# Altium schematic sheet Microcontroller and Power Management.SchDoc
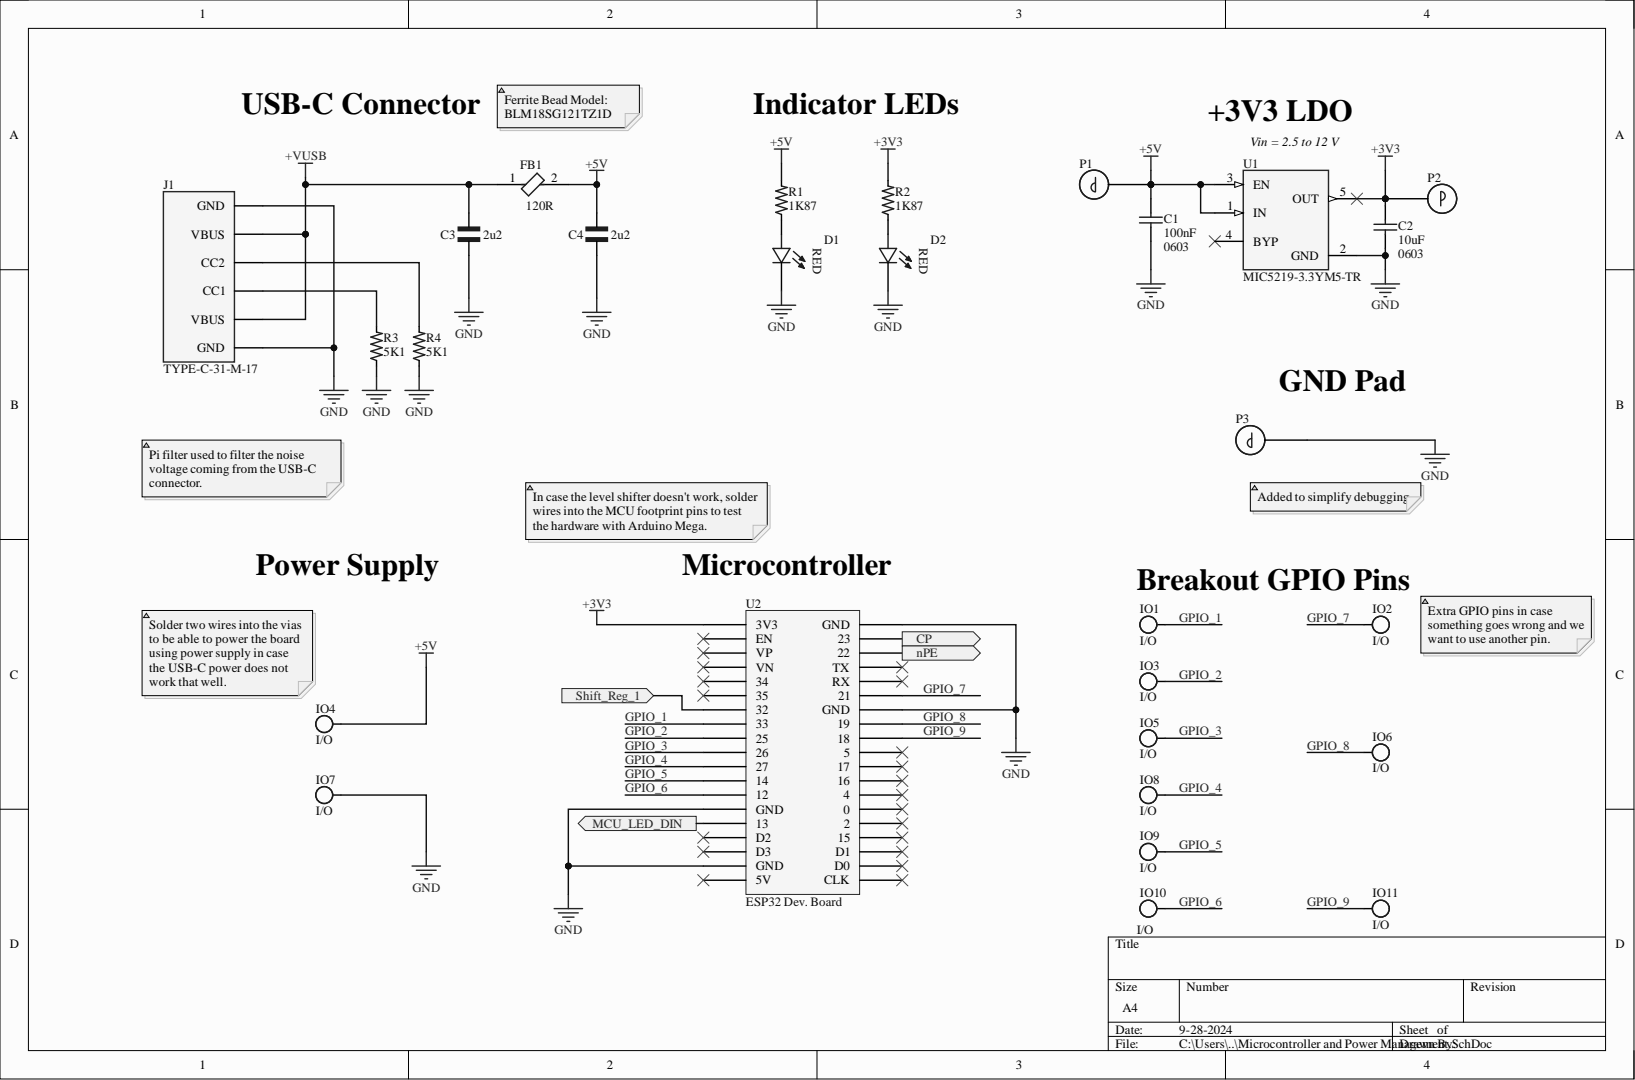
[diagram p0: Microcontroller and Power Management.SchDoc]

## Parts
C1  100nF  value 0603  [CAP 0805]
C2  10uF  value 0603  [CAP 0805]
C3  2u2  [CAP 0805]
C4  2u2  [CAP 0805]
D1  LED 0805  [LED_0805]  (value not drawn)
D2  LED 0805  [LED_0805]  (value not drawn)
FB1  120R  [FP-BLM21-0_2-t0_85-e0_5-IPC_A]
IO1  I/O
IO2  I/O
IO3  I/O
IO4  I/O  [I/O]
IO5  I/O
IO6  I/O
IO7  I/O  [I/O]
IO8  I/O
IO9  I/O
IO10  I/O
IO11  I/O
J1  TYPE-C-31-M-17  [TYPEC31M17]
P1  Probe_5019  [Testpoint]  (value not drawn)
P2  Probe_5019  [Testpoint]  (value not drawn)
P3  Probe_5019  [Testpoint]  (value not drawn)
R1  1K87  [res 0805]
R2  1K87  [res 0805]
R3  5K1  [res 0805]
R4  5K1  [res 0805]
U1  MIC5219-3.3YM5-TR  [FP-SOT23-5LD-PL-1-IPC_C]
U2  ESP32 Dev. Board

## Ports
CP, MCU_LED_DIN, nPE, Shift_Reg_1

## Nets
+3V3: C2.2 P2.1 R2.2 U1.5(OUT) U2.1(3V3)
+5V: C1.2 C4.2 FB1.2 IO4.1 P1.1 R1.2 U1.1(IN) U1.3(EN)
+VUSB: C3.2 FB1.1 J1.2(VBUS) J1.5(VBUS)
GND: C1.1 C2.1 C3.1 C4.1 D1.1 D2.1 IO7.1 J1.1(GND) J1.6(GND) P3.1 R3.1 R4.1 U1.2(GND) U2.14(GND) U2.18(GND) U2.32(GND) U2.38(GND)
CP: U2.37 ; port CP
GPIO_1: IO1.1 U2.8
GPIO_2: IO3.1 U2.9
GPIO_3: IO5.1 U2.10
GPIO_4: IO8.1 U2.11
GPIO_5: IO9.1 U2.12
GPIO_6: IO10.1 U2.13
GPIO_7: IO2.1 U2.33
GPIO_8: IO6.1 U2.31
GPIO_9: IO11.1 U2.30
MCU_LED_DIN: U2.15 ; port MCU_LED_DIN
nPE: U2.36 ; port nPE
Shift_Reg_1: U2.7 ; port Shift_Reg_1
NetD1_2: D1.2 R1.1
NetD2_2: D2.2 R2.1
NetJ1_3: J1.3(CC1) R3.2
NetJ1_4: J1.4(CC2) R4.2

Not connected: U1 4(BYP); U2 2(EN) 3(VP) 4(VN) 5 6 16(D2) 17(D3) 19(5V) 20(CLK) 21(D0) 22(D1) 23 24 25 26 27 28 29 34(RX) 35(TX)

## Library data of the parts
C1 C2 = Cap: Capacitor; Component Kind: Standard; LatestRevisionDate: 17-Jul-2002; LatestRevisionNote: Re-released for DXP Platform.; Library Name: Miscellaneous Devices.IntLib; PackageDescription: Radial Cap, Thru-Hole; 2 Leads; 0.3 in Pin Spacing; PackageReference: RAD-0.3; Published: 8-Jun-2000; Signal Integrity: Cap; Simulation: CAP; Publisher: Altium Limited
C3 C4 = CAP 0805: Check_prices: https://www.snapeda.com/parts/C2012X8R1H104K125AA/TDK/view-part/?ref=eda; Component Kind: Standard; SnapEDA_Link: https://www.snapeda.com/parts/C2012X8R1H104K125AA/TDK/view-part/?ref=snap; Library Name: ChessBoardSchLib.SchLib
D1 D2 = LED 0805: Red LED Indication - Discrete 1.7V 0805 (2012 Metric); MP: 7012X1; Availability: Unavailable; Component Kind: Standard; Package: None; Price: None; Library Name: ChessBoardSchLib.SchLib
FB1 = CMP-0686-00716-3: FERRITE BEAD 120 OHM 0603 1LN; Current Rating: 800mA; DC Current: 200mA; DC Resistance (DCR): 100mR; Frequency: 100MHz; Material: Steel; Max Current Rating: 1A; Number of Circuits: 1; Resistance: 150mR; Shielding: Unshielded; Tolerance: 25%; Case Code (Imperial): 0805; Case Code (Metric): 2012; Case/Package: 0805; Component Kind: Standard; Core Material: Ferrite; DC Resistance-Max: 100mR; Depth: 1.25mm; Height: 0.85mm; Impedance: 120R; Lead Free: Lead Free; Length: 2mm; Library Name: Altium Content Vault; Max DC Current: 200mA; Max Operating Temperature: 125°C; Military Standard: Not; Min Operating Temperature: -55°C; Number of Lines: 1; Number of Pins: 2; Package Quantity: 1; Packaging: Tape and Reel; Radiation Hardening: No; REACH SVHC: No SVHC; Series: BLM21A; Termination: SMD/SMT; Test Frequency: 100MHz; Width: 1.25mm
J1 = TYPE-C-31-M-17: TYPE-C-31-M-17 HROPARTS; MF: HRO Electronics Co., Ltd.; Check_prices: https://www.snapeda.com/parts/TYPE-C-31-M-17/HRO+Electronics+Co.%252C+Ltd./view-part/?ref=eda; Component Kind: Standard; MP: TYPE-C-31-M-17; Package: Package; SnapEDA_Link: https://www.snapeda.com/parts/TYPE-C-31-M-17/HRO+Electronics+Co.%252C+Ltd./view-part/?ref=snap; Library Name: ChessBoardSchLib.SchLib
P1-P3 = Probe_5019: Phosphor Bronze Contact Miniature Silver Plated Surface Mount Test Points; Manufacturer: Keystone Electronics; Manufacturer Part Number: 5019; Body Material: Bronze; Category: Connectors; Component Kind: Standard; ComponentLink1Description: https://datasheet.ciiva.com/16318/m60-2p61-16318395.pdf?src-supplier=Future+Electronics; ComponentLink1URL: https://datasheet.ciiva.com/16318/m60-2p61-16318395.pdf?src-supplier=Future+Electronics; ComponentLink2Description: https://datasheet.ciiva.com/16885/70182998-16885945.pdf?src-supplier=Allied+Electronics; ComponentLink2URL: https://datasheet.ciiva.com/16885/70182998-16885945.pdf?src-supplier=Allied+Electronics; ComponentLink3Description: https://datasheet.ciiva.com/14848/m60-2p61-14848437.pdf?src-supplier=Digi-Key; ComponentLink3URL: https://datasheet.ciiva.com/14848/m60-2p61-14848437.pdf?src-supplier=Digi-Key; ComponentLink4Description: https://datasheet.ciiva.com/14848/m60-2p61-14848438.pdf?src-supplier=Digi-Key; ComponentLink4URL: https://datasheet.ciiva.com/14848/m60-2p61-14848438.pdf?src-supplier=Digi-Key; ComponentLink5Description: https://datasheet.ciiva.com/14848/m60-2p61-14848439.pdf?src-supplier=Digi-Key; ComponentLink5URL: https://datasheet.ciiva.com/14848/m60-2p61-14848439.pdf?src-supplier=Digi-Key; Contact Material: Bronze; Contact Plating: Silver; Height: 0.09inch; Lead Free: Lead Free; Material: Bronze; Mount: Surface Mount,PCB; Number of Pins: 1; Package Quantity: 1; Packaging: Tape and Reel; Plating: Silver; Pricing 1: 1=0.12300, 1000=0.12300, 2000=0.11700, 4000=0.11300, 6000=0.11000 (USD); Reach SVHC Compliant: Yes; RoHS: Yes; RoHS Compliant: Yes; Series: 5019; Stock 1: 148000; Supplier 1: Newark; Supplier Part Number 1: 06W4636; Termination: SMD/SMT; Library Name: MiniChessBoardSchLib.SchLib
R1-R4 = Resistor 0805: MF: TE Connectivity; MP: 2-2176053-2; Power_Rating: .25 W; Resistance: .39; Tolerance: 1%; Availability: Unavailable; Component Kind: Standard; EU_RoHS_Compliance: Compliant with Exemptions; Package: 0805; Price: None; SnapEDA_Link: https://www.snapeda.com/parts/2-2176053-2/TE%20Connectivity/view-part/2214511/?ref=snap; Library Name: ChessBoardSchLib.SchLib
U1 = CMP-06324-000027-1: IC REG LINEAR 3.3V 500MA SOT23-5; Dropout Voltage: 350mV; Max Input Voltage: 12V; Min Input Voltage: 2.5V; Number of Regulators: 1; Output Type: Fixed; Case/Package: SOT-23-5; Component Kind: Standard; Lead Free: Lead Free; Library Name: Altium Content Vault; Max Operating Temperature: 125°C; Min Operating Temperature: -40°C; Mount: Surface Mount; Nominal Output Voltage: 3.3V; Number of Pins: 5; Output Current: 500mA; Output Voltage: 3.3V; Packaging: Cut Tape; REACH SVHC: No SVHC
U2 = ESP32 Dev. Board: ESP32-WROOM-32UE Series Evaluation Board 802.11 b/g/n (WiFi, WLAN) Bluetooth Smart Ready 4.x Dual Mode; Manufacturer: Espressif Systems; Manufacturer Part Number: ESP32-DEVKITC-32UE; Category: RF Semiconductors and Devices; Component Kind: Standard; ComponentLink1Description: https://www.espressif.com/sites/default/files/documentation/espressif_products_ordering_information_en.pdf?src-supplier=Digikey; ComponentLink1URL: https://www.espressif.com/sites/default/files/documentation/espressif_products_ordering_information_en.pdf?src-supplier=Digikey; ComponentLink2Description: https://datasheet.octopart.com/ESP32-DEVKITC-32UE-Espressif-Systems-datasheet-165560559.pdf?src-supplier=TME; ComponentLink2URL: https://datasheet.octopart.com/ESP32-DEVKITC-32UE-Espressif-Systems-datasheet-165560559.pdf?src-supplier=TME; Pricing: 1=10 (USD); RoHS: No; Stock: 498; Supplier: Digikey; Supplier Part Number: 1965-ESP32-DEVKITC-32UE-ND; Library Name: ChessBoardSchLib.SchLib
IO1-IO11 = I/O: Component Kind: Standard; Library Name: MiniChessBoardSchLib.SchLib
